# Altium schematic sheet ST Link.SchDoc
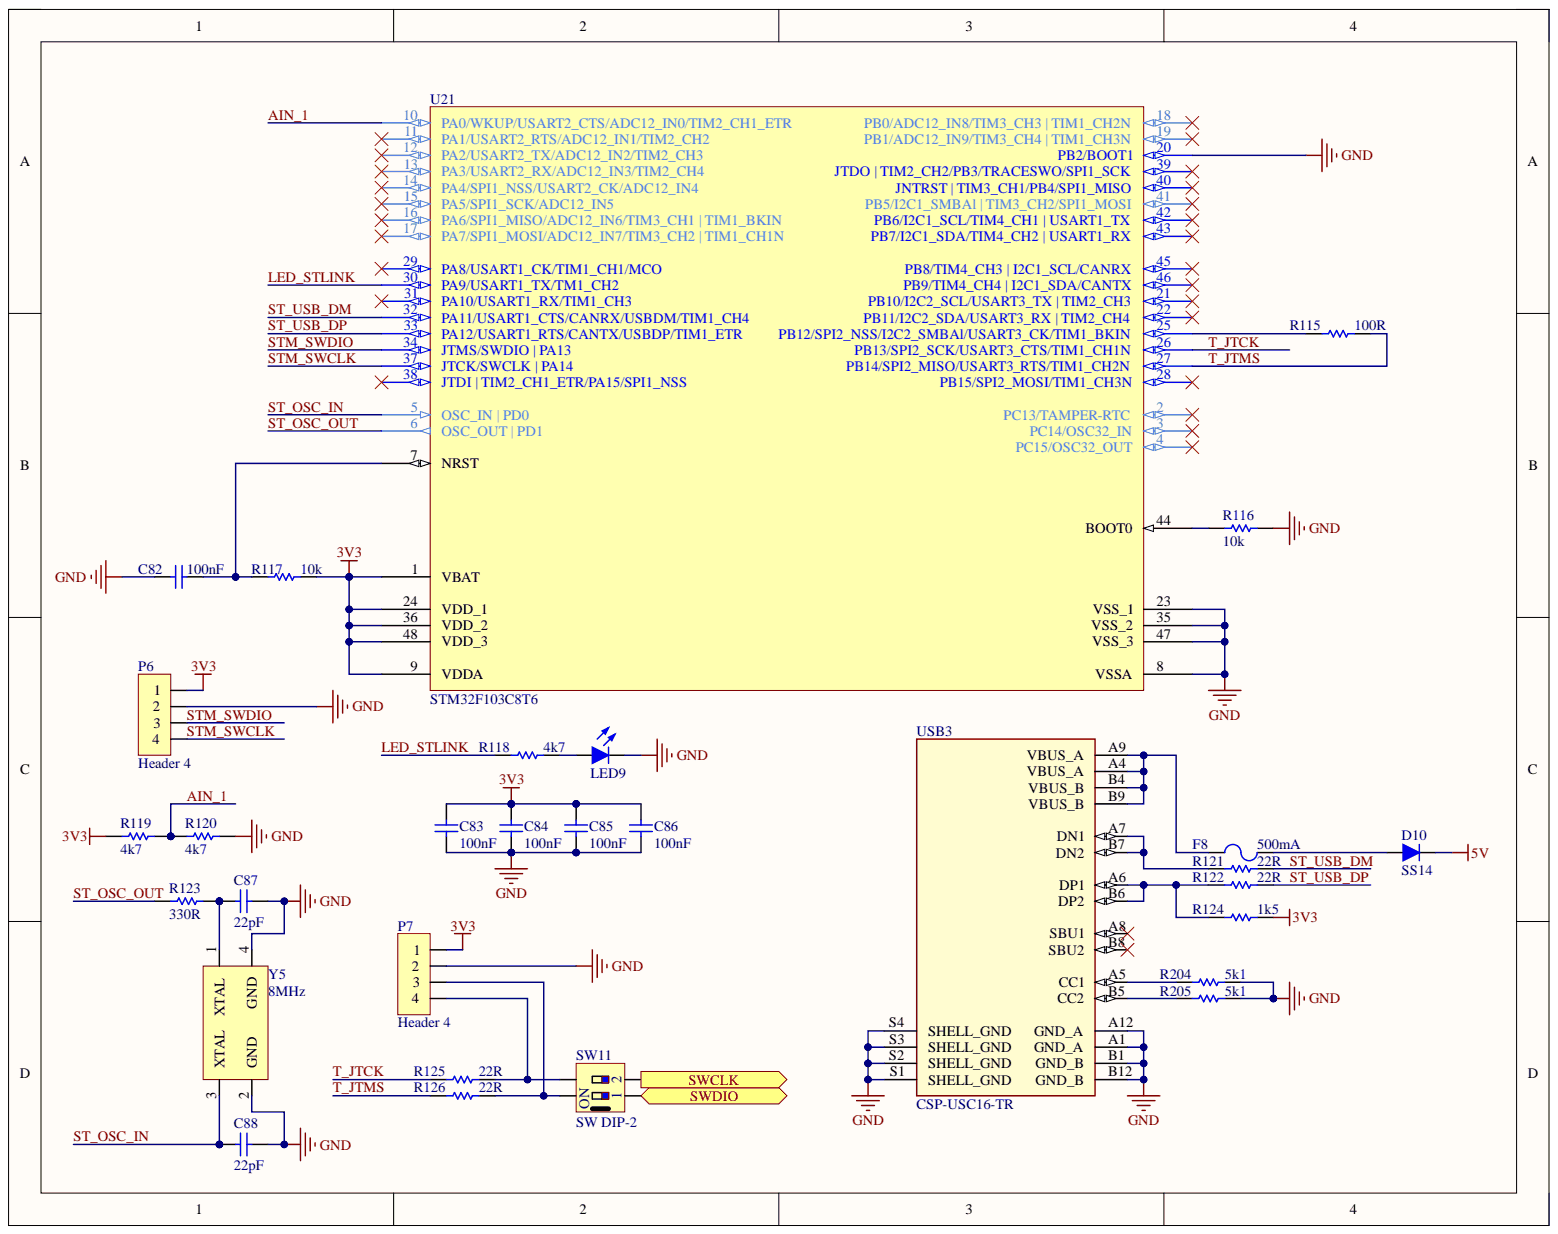
[diagram p0: ST Link.SchDoc]

## Parts
C82  100nF  [C 0603 (1608)]
C83  100nF  [C 0603 (1608)]
C84  100nF  [C 0603 (1608)]
C85  100nF  [C 0603 (1608)]
C86  100nF  [C 0603 (1608)]
C87  22pF  [C 0603 (1608)]
C88  22pF  [C 0603 (1608)]
D10  SS14  [DO-214AC (SMA)]
F8  500mA  [Fuse 0805 SMD]
LED9  Led-Blue  [Led SMD 0603 BLUE]  (value not drawn)
P6  Header 4  [Header 4]
P7  Header 4  [Header 4]
R115  100R  [R 0603 (1608)]
R116  10k  [R 0603 (1608)]
R117  10k  [R 0603 (1608)]
R118  4k7  [R 0603 (1608)]
R119  4k7  [R 0603 (1608)]
R120  4k7  [R 0603 (1608)]
R121  22R  [R 0603 (1608)]
R122  22R  [R 0603 (1608)]
R123  330R  [R 0603 (1608)]
R124  1k5  [R 0603 (1608)]
R125  22R  [R 0603 (1608)]
R126  22R  [R 0603 (1608)]
R204  5k1  [R 0603 (1608)]
R205  5k1  [R 0603 (1608)]
SW11  SW DIP-2  [SW/DIP2]
U21  STM32F103C8T6  [LQFP48_N]
USB3  CSP-USC16-TR  [CSP-USC16-TR]
Y5  8MHz  [Crystal SMD 4PIN 3225]

## Ports
SWCLK, SWDIO

## Nets
3V3: C83.2 C84.2 C85.2 C86.2 P6.1 P7.1 R117.2 R119.2 R124.2 U21.1(VBAT) U21.9(VDDA) U21.24(VDD_1) U21.36(VDD_2) U21.48(VDD_3)
5V: D10.2
GND: C82.2 C83.1 C84.1 C85.1 C86.1 C87.2 C88.2 LED9.2 P6.2 P7.2 R116.2 R120.1 R204.2 R205.2 U21.8(VSSA) U21.20(PB2/BOOT1) U21.23(VSS_1) U21.35(VSS_2) U21.47(VSS_3) USB3.A1(GND_A) USB3.A12(GND_A) USB3.B1(GND_B) USB3.B12(GND_B) USB3.S1(SHELL_GND) USB3.S2(SHELL_GND) USB3.S3(SHELL_GND) USB3.S4(SHELL_GND) Y5.2(GND) Y5.4(GND)
AIN_1: R119.1 R120.2 U21.10(PA0/WKUP/USART2_CTS/ADC12_IN0/TIM2_CH1_ETR)
LED_STLINK: R118.1 U21.30(PA9/USART1_TX/TM1_CH2)
ST_OSC_IN: C88.1 U21.5(OSC_IN_|_PD0) Y5.3(XTAL)
ST_OSC_OUT: R123.2 U21.6(OSC_OUT_|_PD1)
ST_USB_DM: R121.2 U21.32(PA11/USART1_CTS/CANRX/USBDM/TIM1_CH4)
ST_USB_DP: R122.2 U21.33(PA12/USART1_RTS/CANTX/USBDP/TIM1_ETR)
STM_SWCLK: P6.4 U21.37(JTCK/SWCLK_|_PA14)
STM_SWDIO: P6.3 U21.34(JTMS/SWDIO_|_PA13)
SWCLK: SW11.2 ; port SWCLK
SWDIO: SW11.1 ; port SWDIO
T_JTCK: R125.1 U21.26(PB13/SPI2_SCK/USART3_CTS/TIM1_CH1N)
T_JTMS: R115.2 R126.1 U21.27(PB14/SPI2_MISO/USART3_RTS/TIM1_CH2N)
NetC82_1: C82.1 R117.1 U21.7(NRST)
NetC87_1: C87.1 R123.1 Y5.1(XTAL)
NetD10_1: D10.1 F8.2
NetF8_1: F8.1 USB3.A4(VBUS_A) USB3.A9(VBUS_A) USB3.B4(VBUS_B) USB3.B9(VBUS_B)
NetLED9_1: LED9.1 R118.2
NetP7_3: P7.3 R126.2 SW11.4(ON)
NetP7_4: P7.4 R125.2 SW11.3
NetR115_1: R115.1 U21.25(PB12/SPI2_NSS/I2C2_SMBAl/USART3_CK/TIM1_BKIN)
NetR116_1: R116.1 U21.44(BOOT0)
NetR121_1: R121.1 USB3.A7(DN1) USB3.B7(DN2)
NetR122_1: R122.1 R124.1 USB3.A6(DP1) USB3.B6(DP2)
NetR204_1: R204.1 USB3.A5(CC1)
NetR205_1: R205.1 USB3.B5(CC2)

Not connected: U21 2(PC13/TAMPER-RTC) 3(PC14/OSC32_IN) 4(PC15/OSC32_OUT) 11(PA1/USART2_RTS/ADC12_IN1/TIM2_CH2) 12(PA2/USART2_TX/ADC12_IN2/TIM2_CH3) 13(PA3/USART2_RX/ADC12_IN3/TIM2_CH4) 14(PA4/SPI1_NSS/USART2_CK/ADC12_IN4) 15(PA5/SPI1_SCK/ADC12_IN5) 16(PA6/SPI1_MISO/ADC12_IN6/TIM3_CH1_|_TIM1_BKIN) 17(PA7/SPI1_MOSI/ADC12_IN7/TIM3_CH2_|_TIM1_CH1N) 18(PB0/ADC12_IN8/TIM3_CH3_|_TIM1_CH2N) 19(PB1/ADC12_IN9/TIM3_CH4_|_TIM1_CH3N) 21(PB10/I2C2_SCL/USART3_TX_|_TIM2_CH3) 22(PB11/I2C2_SDA/USART3_RX_|_TIM2_CH4) 28(PB15/SPI2_MOSI/TIM1_CH3N) 29(PA8/USART1_CK/TIM1_CH1/MCO) 31(PA10/USART1_RX/TIM1_CH3) 38(JTDI_|_TIM2_CH1_ETR/PA15/SPI1_NSS) 39(JTDO_|_TIM2_CH2/PB3/TRACESWO/SPI1_SCK) 40(JNTRST_|_TIM3_CH1/PB4/SPI1_MISO) 41(PB5/I2C1_SMBAl_|_TIM3_CH2/SPI1_MOSI) 42(PB6/I2C1_SCL/TIM4_CH1_|_USART1_TX) 43(PB7/I2C1_SDA/TIM4_CH2_|_USART1_RX) 45(PB8/TIM4_CH3_|_I2C1_SCL/CANRX) 46(PB9/TIM4_CH4_|_I2C1_SDA/CANTX); USB3 A8(SBU1) B8(SBU2)

## Library data of the parts
C82-C88 = Capacitor NP: Capacitor non-pol; Component Kind: Standard; Library Name: BKIT4U_LIB.SchLib
D10 = Diode: Default Diode; Code_JEDEC: DO-214-AB; Component Kind: Standard; LatestRevisionDate: 17-Jul-2002; LatestRevisionNote: Re-released for DXP Platform.; Library Name: BKIT4U_LIB.SchLib; PackageReference: SMC; PackageVersion: Sep-1996; Published: 8-Jun-2000; Publisher: Altium Limited
F8 = Fuse: Component Kind: Standard; Description: Fuse; Library Name: BKIT4U_LIB.SchLib
LED9 = Led: Typical LED; Component Kind: Standard; Library Name: BKIT4U_LIB.SchLib
P6 P7 = Header 4: Header, 4-Pin; Component Kind: Standard; Library Name: BKIT4U_LIB.SchLib
R115-R126 R204 R205 = Resistor: Component Kind: Standard; Description: Resistor; LatestRevisionDate: 17-Jul-2002; LatestRevisionNote: Re-released for DXP Platform.; Library Name: BKIT4U_LIB.SchLib; PackageReference: AXIAL-0.3; Published: 8-Jun-2000; Publisher: Altium Limited
U21 = STM32F103C8T6: STM32 ARM-based 32-bit MCU with 64 Kbytes Flash, 48-pin LQFP, Industrial Temperature; Component Kind: Standard; ComponentLink1Description: Manufacturer Link; ComponentLink1URL: www.st.com/stm32; ComponentLink2Description: Datasheet; ComponentLink2URL: http://www.st.com/stonline/products/literature/ds/13587.pdf; DatasheetVersion: rev6, Mar-2008; Library Name: BKIT4U_LIB.SchLib; PackageDescription: 48-Pin Low-Profile Quad Flat Pack, 7 x 7 mm Body, 0.5 mm Pitch (LQFP48); PackageReference: LQFP48; PackageVersion: Mar-2008; Published: 30-May-2008; Publisher: Altium Limited
USB3 = CSP-USC16-TR: CSP-USC16-TR Valcon USB Type C Surface Mount PCB Socket; Availability: In Stock; Check_prices: https://www.snapeda.com/parts/CSP-USC16-TR/Valcon/view-part/?ref=eda; Component Kind: Standard; Library Name: BKIT4U_LIB.SchLib; MF: Valcon; MP: CSP-USC16-TR; Package: None; Price: None; Purchase-URL: https://pricing.snapeda.com/search/part/CSP-USC16-TR/?ref=eda; SnapEDA_Link: https://www.snapeda.com/parts/CSP-USC16-TR/Valcon/view-part/?ref=snap
Y5 = Crystal 4pin: Component Kind: Standard; Library Name: BKIT4U_LIB.SchLib
SW11 = SW DIP-2: Component Kind: Standard; Library Name: BKIT4U_LIB.SchLib
